# Altium schematic sheet auxiliary.SchDoc
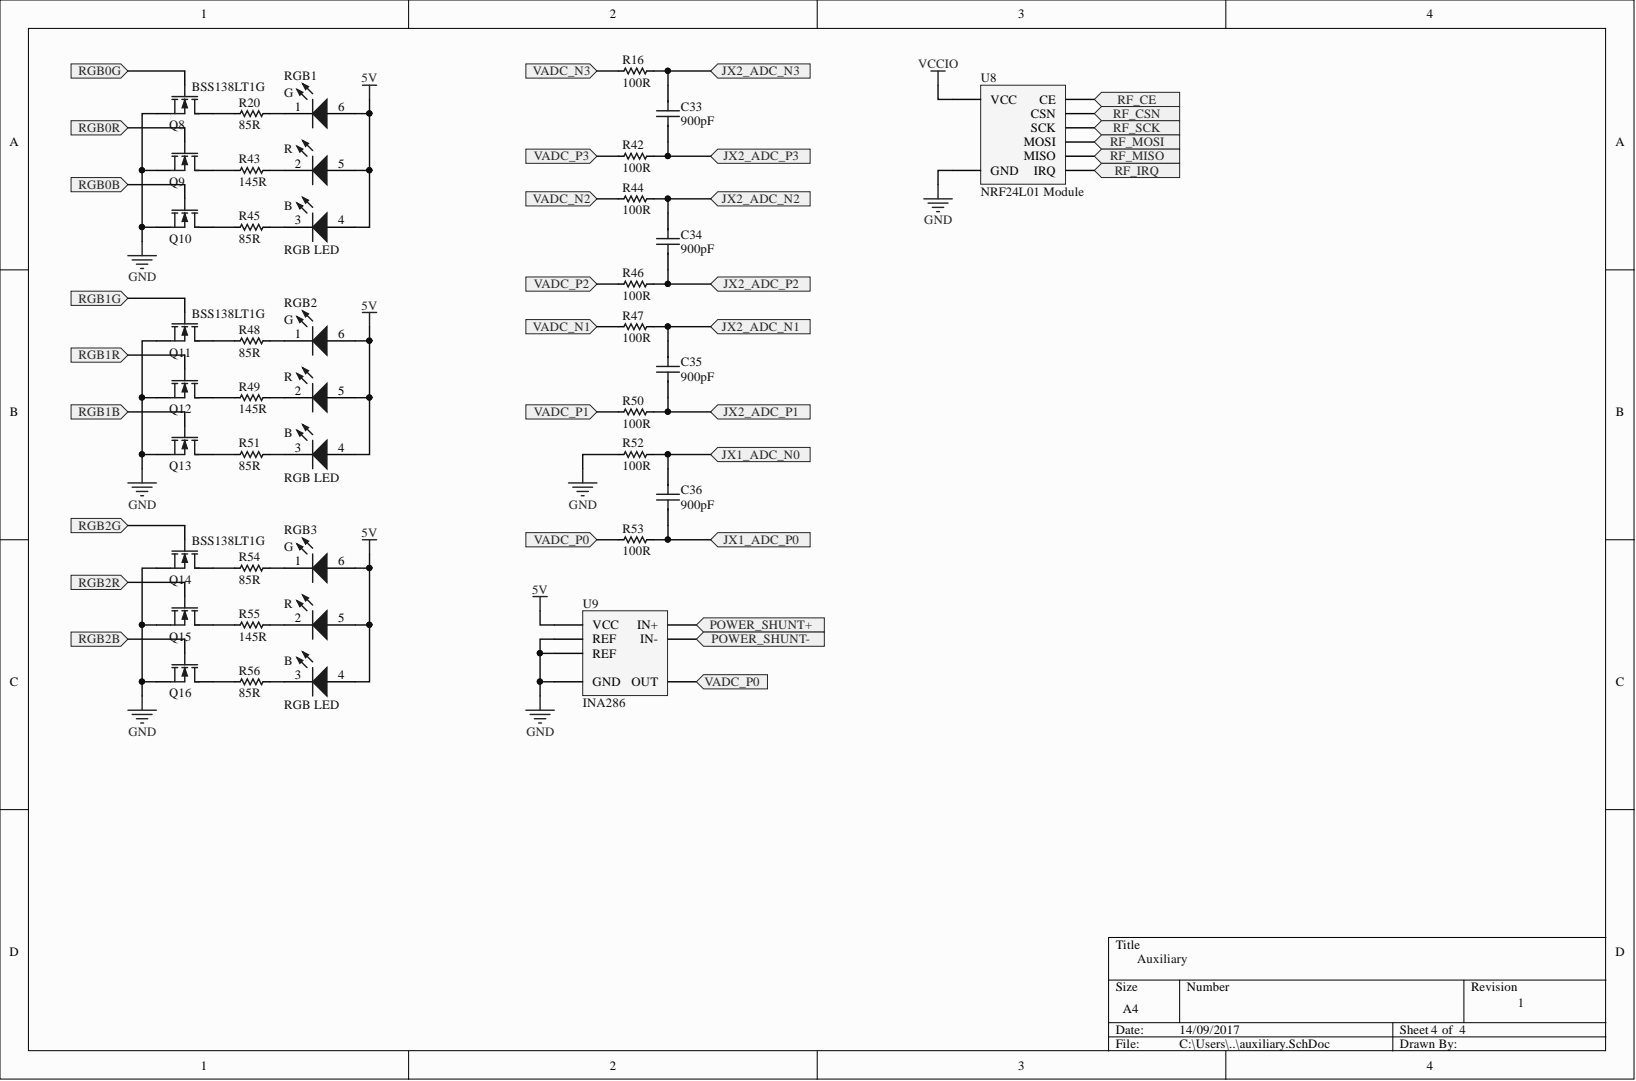
[diagram p0: auxiliary.SchDoc]

## Parts
C33  value 900pF  [CAP-0402]  (hidden comment: Capacitor)
C34  value 900pF  [CAP-0402]  (hidden comment: Capacitor)
C35  value 900pF  [CAP-0402]  (hidden comment: Capacitor)
C36  value 900pF  [CAP-0402]  (hidden comment: Capacitor)
Q8  BSS138LT1G  [SOT-23B]
Q9  BSS138LT1G  [SOT-23B]
Q10  BSS138LT1G  [SOT-23B]
Q11  BSS138LT1G  [SOT-23B]
Q12  BSS138LT1G  [SOT-23B]
Q13  BSS138LT1G  [SOT-23B]
Q14  BSS138LT1G  [SOT-23B]
Q15  BSS138LT1G  [SOT-23B]
Q16  BSS138LT1G  [SOT-23B]
R16  value 100R  [RES-0402]  (hidden comment: Resistor)
R20  value 85R  [0805]  (hidden comment: Resistor)
R42  value 100R  [RES-0402]  (hidden comment: Resistor)
R43  value 145R  [0805]  (hidden comment: Resistor)
R44  value 100R  [RES-0402]  (hidden comment: Resistor)
R45  value 85R  [0805]  (hidden comment: Resistor)
R46  value 100R  [RES-0402]  (hidden comment: Resistor)
R47  value 100R  [RES-0402]  (hidden comment: Resistor)
R48  value 85R  [0805]  (hidden comment: Resistor)
R49  value 145R  [0805]  (hidden comment: Resistor)
R50  value 100R  [RES-0402]  (hidden comment: Resistor)
R51  value 85R  [0805]  (hidden comment: Resistor)
R52  value 100R  [RES-0402]  (hidden comment: Resistor)
R53  value 100R  [RES-0402]  (hidden comment: Resistor)
R54  value 85R  [0805]  (hidden comment: Resistor)
R55  value 145R  [0805]  (hidden comment: Resistor)
R56  value 85R  [0805]  (hidden comment: Resistor)
RGB1  RGB LED  [RGB_LED]
RGB2  RGB LED  [RGB_LED]
RGB3  RGB LED  [RGB_LED]
U8  NRF24L01 Module
U9  INA286  [SOIC-8]

## Ports
JX1_ADC_N0, JX1_ADC_P0, JX2_ADC_N1, JX2_ADC_N2, JX2_ADC_N3, JX2_ADC_P1, JX2_ADC_P2, JX2_ADC_P3, POWER_SHUNT+, POWER_SHUNT-, RF_CE, RF_CSN, RF_IRQ, RF_MISO, RF_MOSI, RF_SCK, RGB0B, RGB0G, RGB0R, RGB1B, RGB1G, RGB1R, RGB2B, RGB2G, RGB2R, VADC_N1, VADC_N2, VADC_N3, VADC_P0, VADC_P1, VADC_P2, VADC_P3

## Nets
5V: RGB1.4 RGB1.5 RGB1.6 RGB2.4 RGB2.5 RGB2.6 RGB3.4 RGB3.5 RGB3.6 U9.6(VCC)
GND: Q8.2 Q9.2 Q10.2 Q11.2 Q12.2 Q13.2 Q14.2 Q15.2 Q16.2 R52.1 U8.2(GND) U9.2(GND) U9.3(REF) U9.7(REF)
VCCIO: U8.1(VCC)
JX1_ADC_N0: C36.2 R52.0 ; port JX1_ADC_N0
JX1_ADC_P0: C36.1 R53.0 ; port JX1_ADC_P0
JX2_ADC_N1: C35.2 R47.0 ; port JX2_ADC_N1
JX2_ADC_N2: C34.2 R44.0 ; port JX2_ADC_N2
JX2_ADC_N3: C33.2 R16.0 ; port JX2_ADC_N3
JX2_ADC_P1: C35.1 R50.0 ; port JX2_ADC_P1
JX2_ADC_P2: C34.1 R46.0 ; port JX2_ADC_P2
JX2_ADC_P3: C33.1 R42.0 ; port JX2_ADC_P3
RF_CE: U8.3(CE) ; port RF_CE
RF_CSN: U8.4(CSN) ; port RF_CSN
RF_IRQ: U8.8(IRQ) ; port RF_IRQ
RF_MISO: U8.7(MISO) ; port RF_MISO
RF_MOSI: U8.6(MOSI) ; port RF_MOSI
RF_SCK: U8.5(SCK) ; port RF_SCK
RGB0B: Q10.1 ; port RGB0B
RGB0G: Q8.1 ; port RGB0G
RGB0R: Q9.1 ; port RGB0R
RGB1B: Q13.1 ; port RGB1B
RGB1G: Q11.1 ; port RGB1G
RGB1R: Q12.1 ; port RGB1R
RGB2B: Q16.1 ; port RGB2B
RGB2G: Q14.1 ; port RGB2G
RGB2R: Q15.1 ; port RGB2R
VADC_N1: R47.1 ; port VADC_N1
VADC_N2: R44.1 ; port VADC_N2
VADC_N3: R16.1 ; port VADC_N3
VADC_P0: R53.1 U9.5(OUT) ; port VADC_P0
VADC_P1: R50.1 ; port VADC_P1
VADC_P2: R46.1 ; port VADC_P2
VADC_P3: R42.1 ; port VADC_P3
NetQ8_3: Q8.3 R20.1
NetQ9_3: Q9.3 R43.1
NetQ10_3: Q10.3 R45.1
NetQ11_3: Q11.3 R48.1
NetQ12_3: Q12.3 R49.1
NetQ13_3: Q13.3 R51.1
NetQ14_3: Q14.3 R54.1
NetQ15_3: Q15.3 R55.1
NetQ16_3: Q16.3 R56.1
NetR20_0: R20.0 RGB1.1
NetR43_0: R43.0 RGB1.2
NetR45_0: R45.0 RGB1.3
NetR48_0: R48.0 RGB2.1
NetR49_0: R49.0 RGB2.2
NetR51_0: R51.0 RGB2.3
NetR54_0: R54.0 RGB3.1
NetR55_0: R55.0 RGB3.2
NetR56_0: R56.0 RGB3.3
NetU9_1: U9.1(IN-)
NetU9_8: U9.8(IN+)

## Library data of the parts
Q8-Q16 = BSS138LT1G: N-Channel MOSFET; Component Kind: Standard; LatestRevisionDate: 17-Jul-2002; LatestRevisionNote: Re-released for DXP Platform.; PackageDescription: TO, Flat Index; 3 In-Line, Axial Leads; Body Dia. 4.6mm; Lead Dia. 0.5 mm (max); PackageReference: E3; PackageVersion: 1995/1996; Published: 8-Jun-2000; Library Name: SDUEmbedded.SchLib; Publisher: Altium Limited
RGB1-RGB3 = LTRB R8SF: Component Kind: Standard; Library Name: SDUEmbedded.SchLib
C33-C36 = Capacitor: Component Kind: Standard; Description: Capacitor; Library Name: SDUEmbedded.SchLib
R16 R20 R42-R56 = Resistor: Component Kind: Standard; Description: Resistor; Library Name: SDUEmbedded.SchLib
U8 = NRF24L01 Module: Component Kind: Standard; Library Name: SDUEmbedded.SchLib
U9 = INA286: Component Kind: Standard; Library Name: SDUEmbedded.SchLib
